# Altium schematic sheet MCU.SchDoc(MCU)
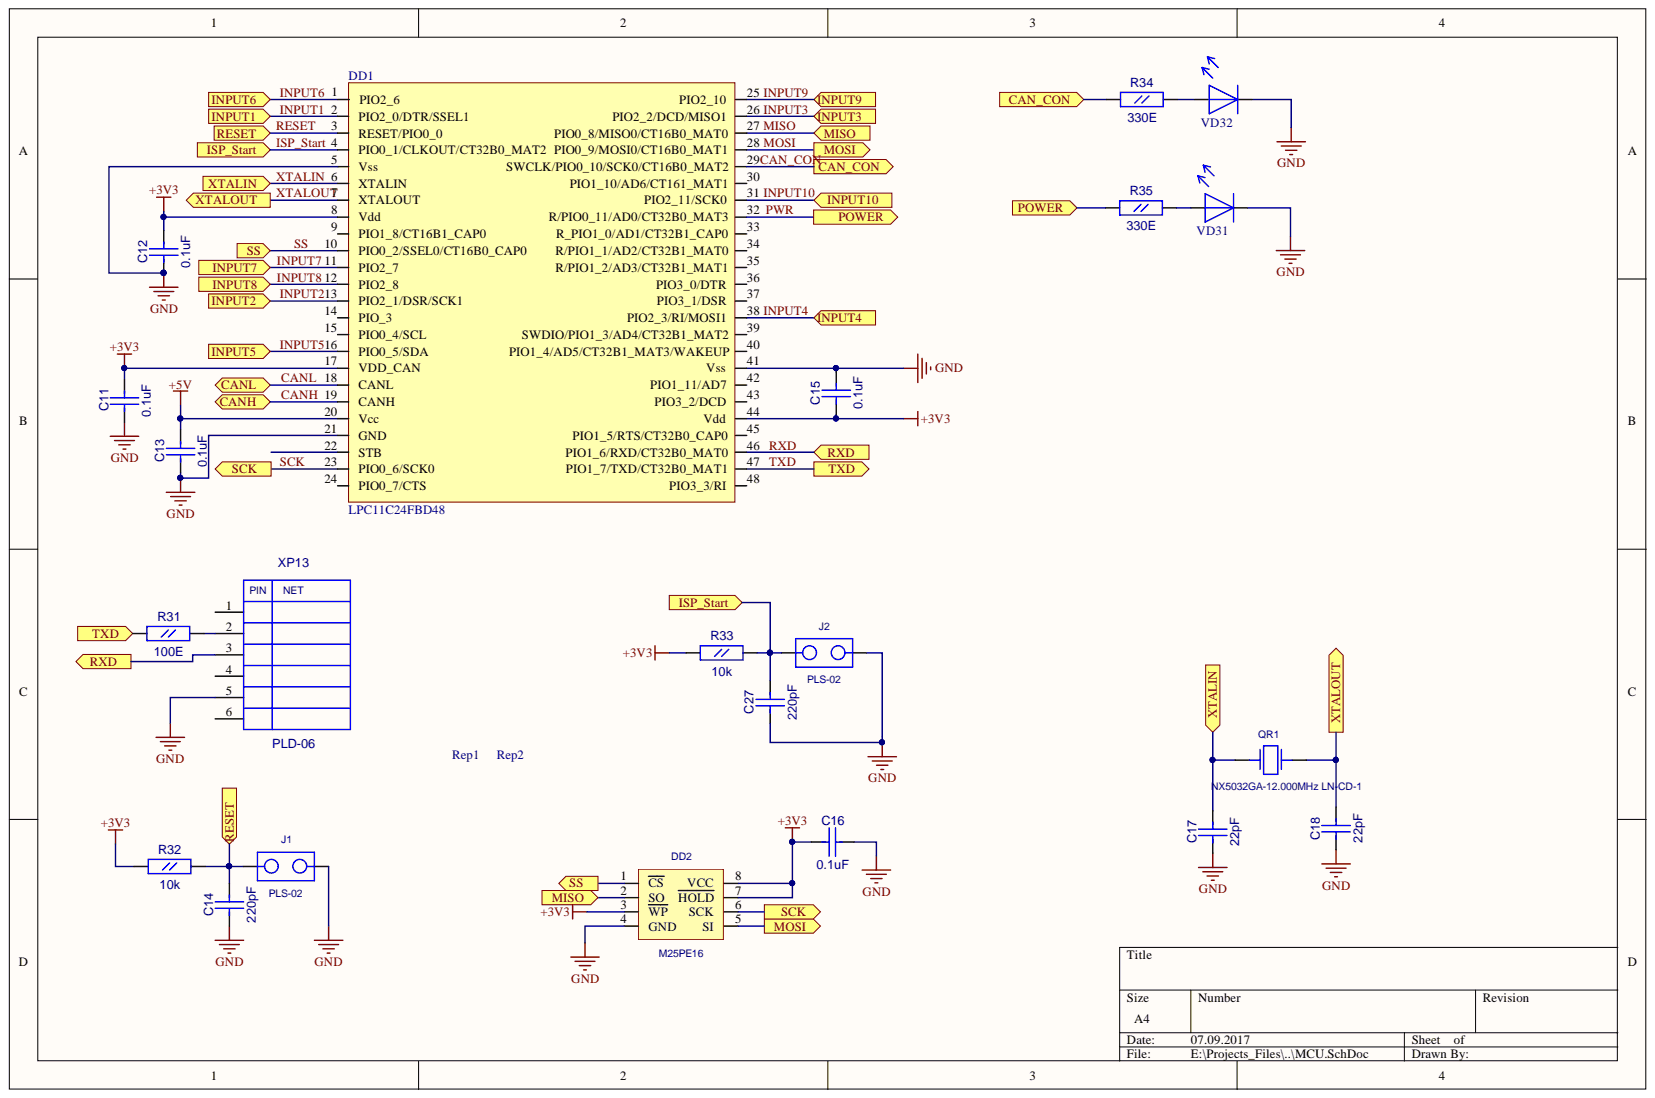
[diagram p0: MCU.SchDoc]

## Parts
C11  0.1uF  [CC0603]
C12  0.1uF  [CC0603]
C13  0.1uF  [CC0603]
C14  220pF  [CC0603]
C15  0.1uF  [CC0603]
C16  0.1uF  [CC0603]
C17  22pF  [CC0603]
C18  22pF  [CC0603]
C27  220pF  [CC0603]
DD1  LPC11C24FBD48  [LQFP-48]
DD2  M25PE16  [EIAJ_SO8]
J1  PLS-02  [PIN2W]
J2  PLS-02  [PIN2W]
QR1  NX5032GA-12.000MHz LN-CD-1  [AC5032_2]
R31  100E  [RC0603]
R32  10k  [RC0603]
R33  10k  [RC0603]
R34  330E  [RC0603]
R35  330E  [RC0603]
Rep1  REPER1.2  [REPER1.2]  (value not drawn)
Rep2  REPER1.2  [REPER1.2]  (value not drawn)
VD31  BL-HS135A-TRB  [LED0805]  (value not drawn)
VD32  BL-HG035A-TRB  [LED0805]  (value not drawn)
XP13  PLD-06  [PIN6]

## Ports
CAN_CON, CANH, CANL, INPUT1, INPUT2, INPUT3, INPUT4, INPUT5, INPUT6, INPUT7, INPUT8, INPUT9, INPUT10, ISP_Start, MISO, MOSI, POWER, RESET, RXD, SCK, SS, TXD, XTALIN, XTALOUT

## Nets
+3V3: C11.2 C12.2 C15.1 C16.1 DD1.8(Vdd) DD1.17(VDD_CAN) DD1.44(Vdd) DD2.3(WP) DD2.7(HOLD) DD2.8(VCC) R32.1 R33.1
+5V: C13.2 DD1.20(Vcc)
GND: C11.1 C12.1 C13.1 C14.1 C15.2 C16.2 C17.1 C18.1 C27.1 DD1.5(Vss) DD1.21(GND) DD1.41(Vss) DD2.4(GND) J1.2 J2.2 VD31.2 VD32.2 XP13.5
CAN_CON: DD1.29(SWCLK/PIO0_10/SCK0/CT16B0_MAT2) R34.1 ; port CAN_CON
CANH: DD1.19(CANH) ; port CANH
CANL: DD1.18(CANL) ; port CANL
INPUT1: DD1.2(PIO2_0/DTR/SSEL1) ; port INPUT1
INPUT2: DD1.13(PIO2_1/DSR/SCK1) ; port INPUT2
INPUT3: DD1.26(PIO2_2/DCD/MISO1) ; port INPUT3
INPUT4: DD1.38(PIO2_3/RI/MOSI1) ; port INPUT4
INPUT5: DD1.16 ; port INPUT5
INPUT6: DD1.1(PIO2_6) ; port INPUT6
INPUT7: DD1.11(PIO2_7) ; port INPUT7
INPUT8: DD1.12(PIO2_8) ; port INPUT8
INPUT9: DD1.25(PIO2_10) ; port INPUT9
INPUT10: DD1.31(PIO2_11/SCK0) ; port INPUT10
ISP_Start: C27.2 DD1.4 J2.1 R33.2 ; port ISP_Start
MISO: DD1.27 DD2.2(SO) ; port MISO
MOSI: DD1.28 DD2.5(SI) ; port MOSI
PWR: DD1.32(R/PIO0_11/AD0/CT32B0_MAT3) R35.1 ; port POWER
RESET: C14.2 DD1.3(RESET/PIO0_0) J1.1 R32.2 ; port RESET
RXD: DD1.46(PIO1_6/RXD/CT32B0_MAT0) XP13.3 ; port RXD
SCK: DD1.23 DD2.6(SCK) ; port SCK
SS: DD1.10 DD2.1(CS) ; port SS
TXD: DD1.47(PIO1_7/TXD/CT32B0_MAT1) R31.1 ; port TXD
XTALIN: C17.2 DD1.6(XTALIN) QR1.1 ; port XTALIN
XTALOUT: C18.2 DD1.7(XTALOUT) QR1.2 ; port XTALOUT
NetR31_2: R31.2 XP13.2
NetR34_2: R34.2 VD32.1
NetR35_2: R35.2 VD31.1

Not connected: DD1 9(PIO1_8/CT16B1_CAP0) 14(PIO_3) 15 22(STB) 24 30(PIO1_10/AD6/CT161_MAT1) 33(R_PIO1_0/AD1/CT32B1_CAP0) 34(R/PIO1_1/AD2/CT32B1_MAT0) 35(R/PIO1_2/AD3/CT32B1_MAT1) 36(PIO3_0/DTR) 37(PIO3_1/DSR) 39(SWDIO/PIO1_3/AD4/CT32B1_MAT2) 40(PIO1_4/AD5/CT32B1_MAT3/WAKEUP) 42(PIO1_11/AD7) 43(PIO3_2/DCD) 45(PIO1_5/RTS/CT32B0_CAP0) 48(PIO3_3/RI); XP13 1 4 6

## Library data of the parts
C11-C18 C27 = CC0603: Description: CC0603; Component Kind: Standard; Library Name: gprs_modem_2.SchLib
  C11-C13 C15 C16: Value: 0.1uF
  C14 C27: Value: 220pF
  C17 C18: Value: 22pF
DD2 = S25FL016A0LMFI01: Value: M25PE16; Description: S25FL016A0LMFI01; Component Kind: Standard; Library Name: gprs_modem_2.SchLib
QR1 = AC5032_2: AC5032/2; Value: NX5032GA-12.000MHz LN-CD-1; Component Kind: Standard; Library Name: gprs_modem_2.SchLib
R31-R35 = RC0603: Description: RC0603; Component Kind: Standard; Library Name: gprs_modem_2.SchLib
  R31: Value: 100E
  R32 R33: Value: 10k
  R34 R35: Value: 330E
VD31 VD32 = LED_0805: Component Kind: Standard; Library Name: gprs_modem_2.SchLib
  VD31: Value: BL-HS135A-TRB
  VD32: Value: BL-HG035A-TRB
XP13 = PLD-06: PLD06; Component Kind: Standard; Library Name: gprs_modem.SchLib
DD1 = LPC11C24FBD48: Value: LPC11C24FBD48; Component Kind: Standard; Library Name: gprs_modem_2.SchLib
J1 J2 = PLS-02: Description: PLS-02; Component Kind: Standard; Library Name: gprs_modem_2.SchLib
Rep1 Rep2 = REPER1.2: Description: REPER1.2; Component Kind: Standard; Library Name: gprs_modem_2.SchLib
